# Altium schematic sheet Alpha_mini.SchDoc
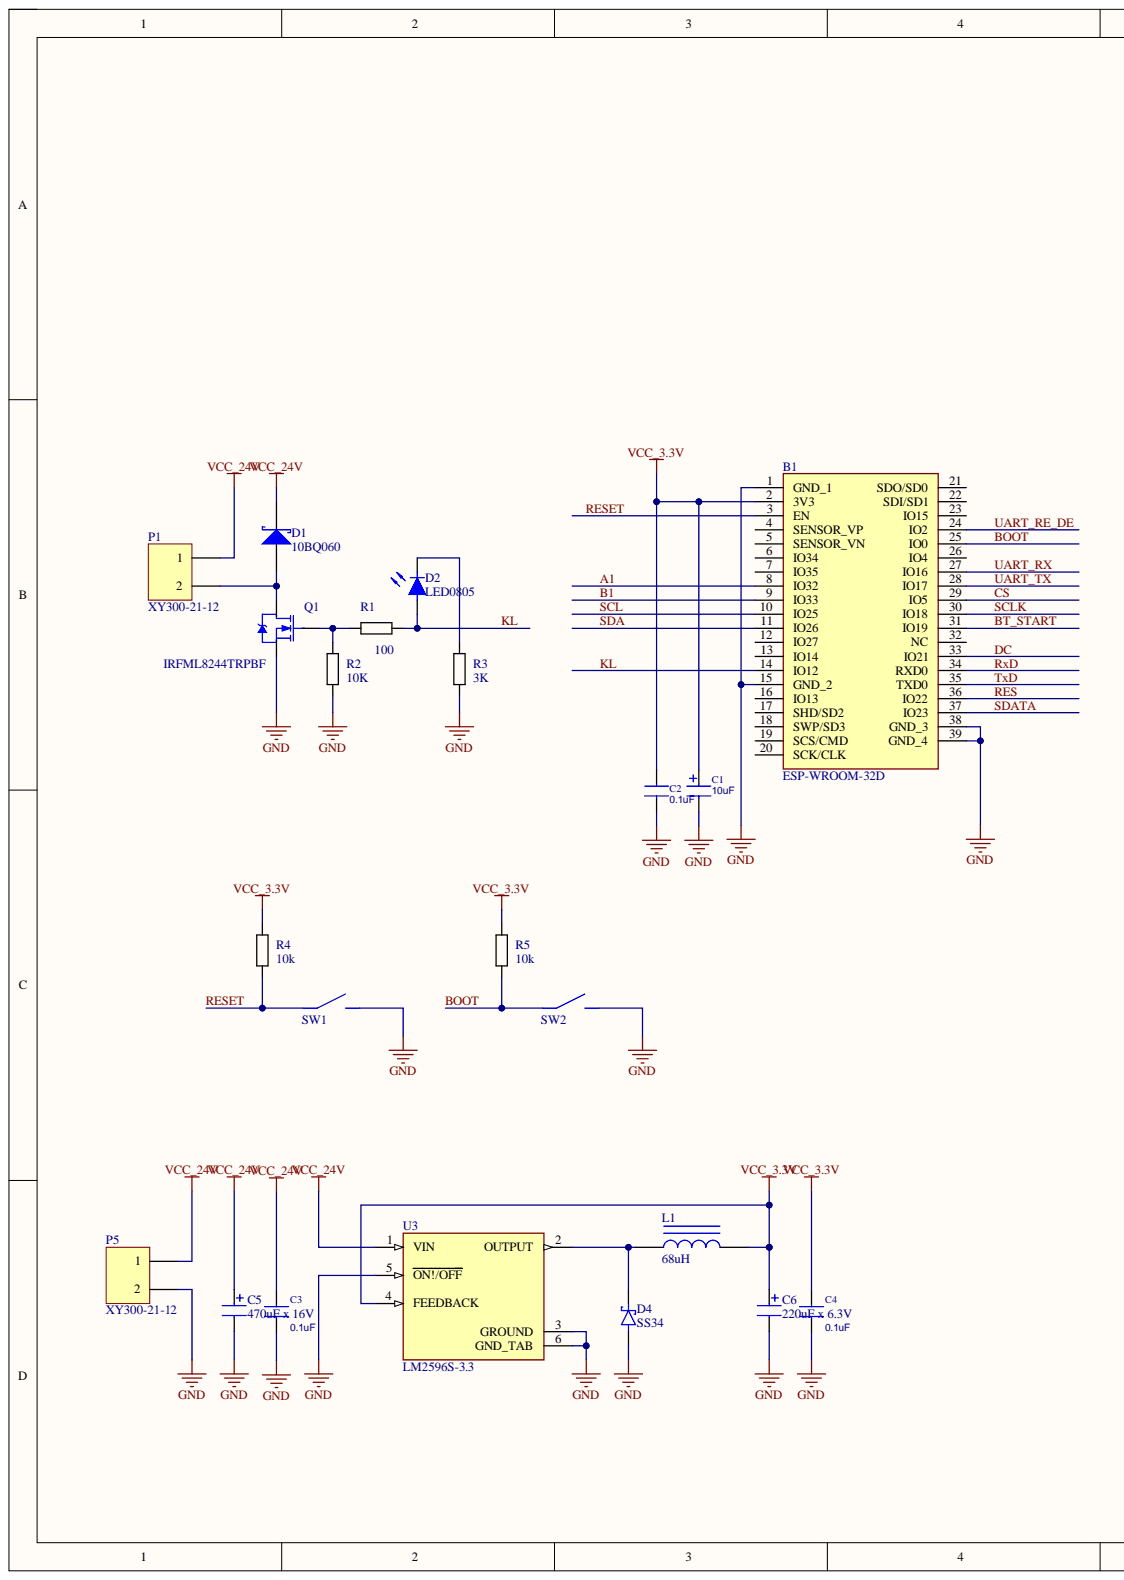
[diagram p0: Alpha_mini.SchDoc, left]
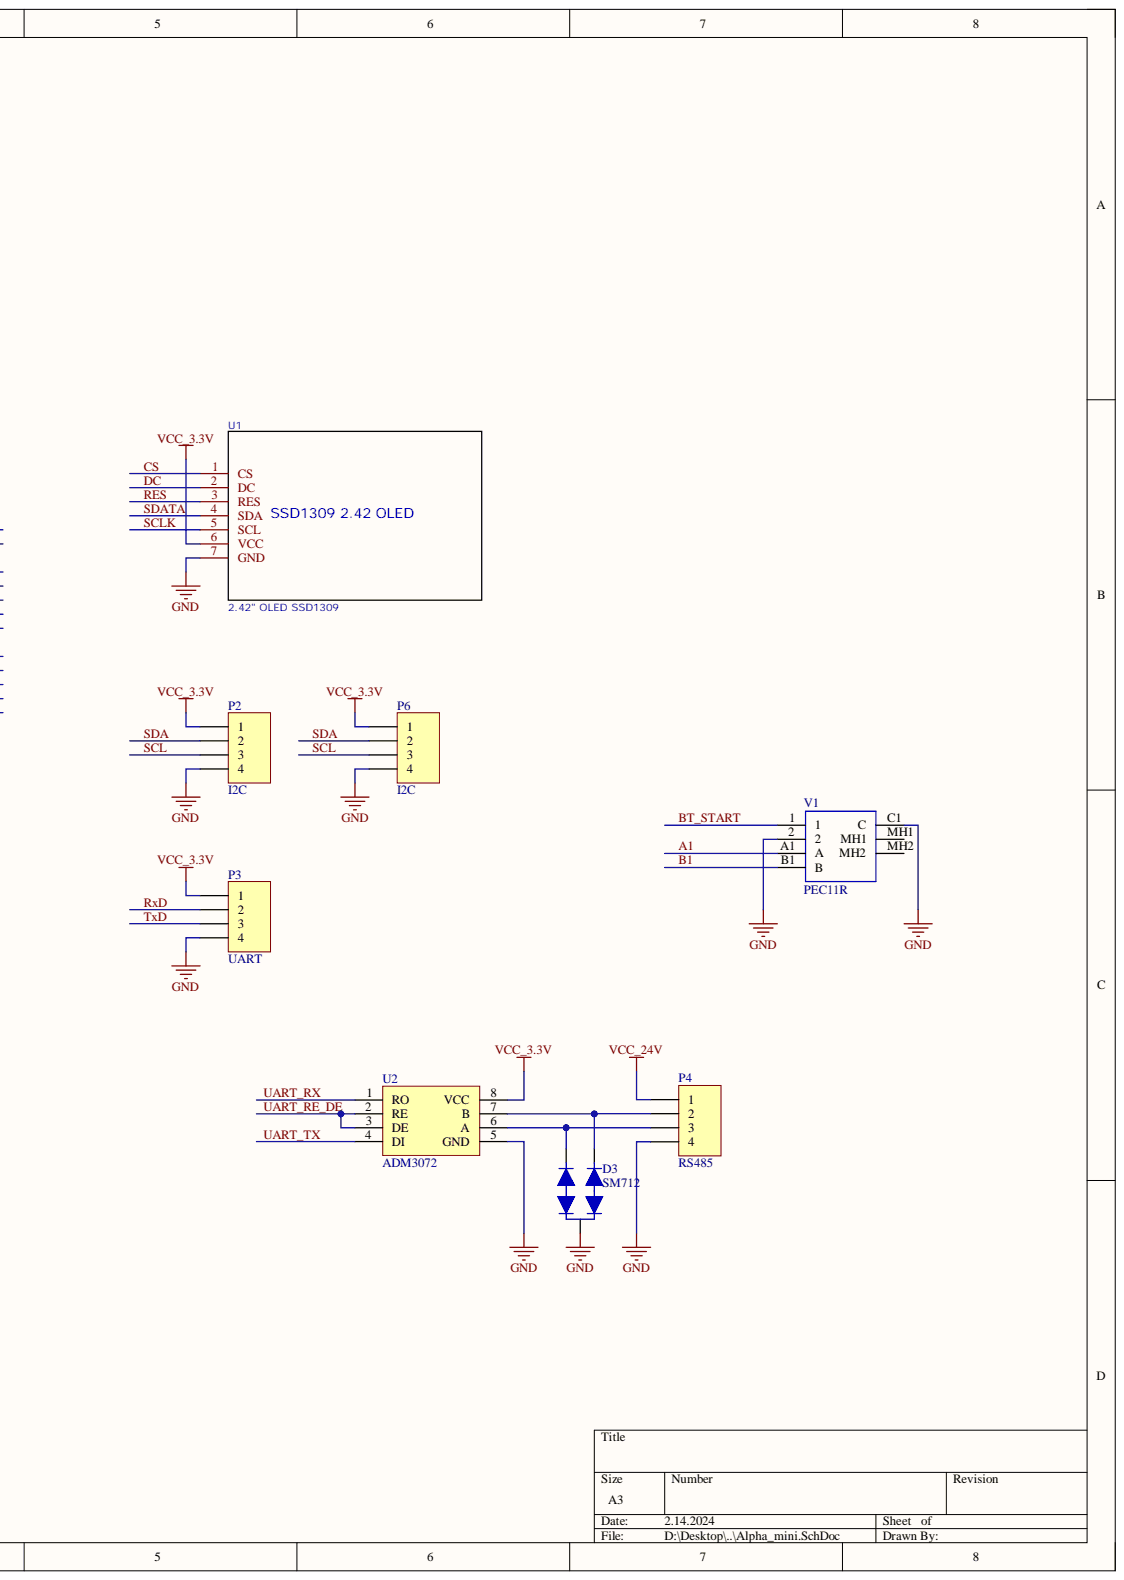
[diagram p1: Alpha_mini.SchDoc, right]

## Parts
B1  ESP-WROOM-32D  [ESPWROOM32D]
C1  10uF  [CPM3528]
C2  0.1uF  [C_0805]
C3  0.1uF  [C_0805]
C4  0.1uF  [C_0805]
C5  470uF x 16V  [Radial 8x11.5]
C6  220uF x 6.3V  [Radial 8x11.5]
D1  10BQ060  [SMB]
D2  LED0805  [led0805]
D3  SM712  [SOT23]
D4  SS34  [DIOM6859X262N]
L1  value 68uH  [L4028]  (hidden comment: 33uH)
P1  XY300-21-12  [XY300-21-12]
P2  I2C  [HDR1X4]
P3  UART  [HDR1X4]
P4  RS485  [HDR1X4]
P5  XY300-21-12  [XY300-21-12]
P6  I2C  [HDR1X4]
Q1  IRFML8244TRPBF  [SOT23-3]
R1  100  [Resistor 0805]
R2  10K  [Resistor 0805]
R3  3K  [Resistor 0805]
R4  10k  [Resistor 0805]
R5  10k  [Resistor 0805]
SW1  Button  [1184]  (value not drawn)
SW2  Button  [1184]  (value not drawn)
U1  2.42" OLED SSD1309  [2.42" OLED]
U2  ADM3072  [SO-8]
U3  LM2596S-3.3  [TO170P1524X483-6N]
V1  PEC11R  [PEC11R]

## Nets
GND: B1.1(GND_1) B1.15(GND_2) B1.38(GND_3) B1.39(GND_4) C1.2 C2.1 C3.1 C4.1 C5.2 C6.2 D3.3 D4.A P2.4 P3.4 P4.4 P5.2 P6.4 Q1.5 R2.2 R3.2 SW1.1 SW2.1 U1.7(GND) U2.5(GND) U3.3(GROUND) U3.5(ON!/OFF) U3.6(GND_TAB) V1.2 V1.C1(C)
VCC_3.3V: B1.2(3V3) C1.1 C2.2 C4.2 C6.1 L1.2 P2.1 P3.1 P6.1 R4.2 R5.2 U1.6(VCC) U2.8(VCC) U3.4(FEEDBACK)
VCC_24V: C3.2 C5.1 D1.2 P1.1 P4.1 P5.1 U3.1(VIN)
A1: B1.8(IO32) V1.A1(A)
B1: B1.9(IO33) V1.B1(B)
BOOT: B1.25(IO0) R5.1 SW2.0
BT_START: B1.31(IO19) V1.1
CS: B1.29(IO5) U1.1(CS)
DC: B1.33(IO21) U1.2(DC)
KL: B1.14(IO12) D2.1 R1.1
RES: B1.36(IO22) U1.3(RES)
RESET: B1.3(EN) R4.1 SW1.0
RxD: B1.34(RXD0) P3.2
SCL: B1.10(IO25) P2.3 P6.3
SCLK: B1.30(IO18) U1.5(SCL)
SDA: B1.11(IO26) P2.2 P6.2
SDATA: B1.37(IO23) U1.4(SDA)
TxD: B1.35(TXD0) P3.3
UART_RE_DE: B1.24(IO2) U2.2(RE) U2.3(DE)
UART_RX: B1.27(IO16) U2.1(RO)
UART_TX: B1.28(IO17) U2.4(DI)
NetD1_1: D1.1 P1.2 Q1.2
NetD2_2: D2.2 R3.1
NetD3_1: D3.1 P4.2 U2.7(B)
NetD3_2: D3.2 P4.3 U2.6(A)
NetD4_C: D4.C L1.1 U3.2(OUTPUT)
NetQ1_4: Q1.4 R1.2 R2.1

Not connected: B1 4(SENSOR_VP) 5(SENSOR_VN) 6(IO34) 7(IO35) 12(IO27) 13(IO14) 16(IO13) 17(SHD/SD2) 18(SWP/SD3) 19(SCS/CMD) 20(SCK/CLK) 21(SDO/SD0) 22(SDI/SD1) 23(IO15) 26(IO4) 32(NC); V1 MH1 MH2

## Library data of the parts
B1 = ESP-WROOM-32D: WiFi Modules (802.11) ESP32-D0WD 32 Mbits, 3.3 V 40 MHz onboard antenna (18.00+/-0.10) x (25.50+/-0.10) x (3.10+/-0.10); Manufacturer_Name: Espressif Systems; Geometry.Height: 3.2mm; Component Kind: Standard; Datasheet Link: https://componentsearchengine.com/Datasheets/1/ESP-WROOM-32D.pdf; Library Name: My.IntLib; Manufacturer_Part_Number: ESP-WROOM-32D; Mouser Part Number: 356-ESP-WROOM-32D; Mouser Price/Stock: https://www.mouser.co.uk/ProductDetail/Espressif-Systems/ESP-WROOM-32D?qs=MLItCLRbWsyWVKgdQMcFuQ%3D%3D
C1 = CPM3528: Capacitor; Component Kind: Standard; Library Name: AX_Altium_v2.3.IntLib; Value: 10uF
C2-C4 = C0805: Capacitor; Component Kind: Standard; Library Name: AX_Altium_v2.3.IntLib; Value: 0.1uF
C5 C6 = Cap Radial: Component Kind: Standard; Library Name: My.IntLib
D1 = 10BQ060: Schottky Rectifier; Component Kind: Standard; ComponentLink1Description: Manufacturer Link; ComponentLink1URL: http://www.irf.com/; ComponentLink2Description: Datasheet; ComponentLink2URL: http://www.irf.com/product-info/datasheets/data/10bq60.pdf; DatasheetVersion: PD-2.438A; LatestRevisionDate: 17-Jul-2002; LatestRevisionNote: Re-released for DXP Platform.; Library Name: IR Rectifier - Schottky.IntLib; PackageDescription: DO-214-AA/SMB; 2 C-Bend Leads; Body 5.3 x 3.6 mm, inc. leads (LxW); PackageReference: SMB; Published: 8-Jun-2000; Signal Integrity: 10BQ060; Simulation: 10BQ060; Publisher: Altium Limited
L1 = Inductor Iron: SRU6025-330Y; Component Kind: Standard; Published: 17-Mar-2010; Library Name: Sergeev_altium_2012.03.10.IntLib; Publisher: Sergeev
P2-P4 P6 = Header 4: Header, 4-Pin; Component Kind: Standard; LatestRevisionDate: 17-Jul-2002; LatestRevisionNote: Re-released for DXP Platform.; Library Name: Miscellaneous Connectors.IntLib; Signal Integrity: Connector; Publisher: Altium Limited
R1-R5 = Resistor: Component Kind: Standard; Library Name: Maks_lib.IntLib
  R1: Value: 100
  R2: Value: 10K
  R3: Value: 3K
  R4 R5: Value: 10k
U2 = MAX3362AKA: Component Kind: Standard; Library Name: My.IntLib
U3 = LM2596S-5.0: SIMPLE SWITCHER&reg; 4.5V to 40V, 3A Low Component Count Step-Down Regulator 5-DDPAK/TO-263; MF: Texas Instruments; MP: LM2596S-5.0/NOPB; Availability: Good; Check_prices: https://www.snapeda.com/parts/LM2596S-5.0/NOPB/Texas%20Instruments/view-part/15588/?ref=eda; Component Kind: Standard; DigiKey-Purchase-URL: https://snapeda.com/shop?store=DigiKey&id=15588; Library Name: LM2596S-5.0.IntLib; Mouser-Purchase-URL: https://snapeda.com/shop?store=Mouser&id=15588; Package: TO-263-5 Texas Instruments; Price: None; SnapEDA_Link: https://www.snapeda.com/parts/LM2596S-5.0/NOPB/Texas%20Instruments/view-part/15588/?ref=snap; Texas_Instruments-Purchase-URL: https://snapeda.com/shop?store=Texas+Instruments&id=15588
D2 = LED0805: Component Kind: Standard; Library Name: My.IntLib
D3 = SM712: Component Kind: Standard; Library Name: My.IntLib
D4 = SS34: Availability: Unavailable; Component Kind: Standard; Library Name: SS34.IntLib; MP: SS34; Package: None; Price: None
P1 P5 = XY300-21-12: Component Kind: Standard; Library Name: My.IntLib
Q1 = IRFML8244TRPBF: Component Kind: Standard; Library Name: My.IntLib
SW1 SW2 = Button: Component Kind: Standard; Library Name: My.IntLib
U1 = 2.42" OLED SSD1309: Component Kind: Standard; Library Name: My.IntLib
V1 = PEC11R: Component Kind: Standard; Library Name: My.IntLib
